# Altium schematic sheet RTC.SchDoc(RTC)
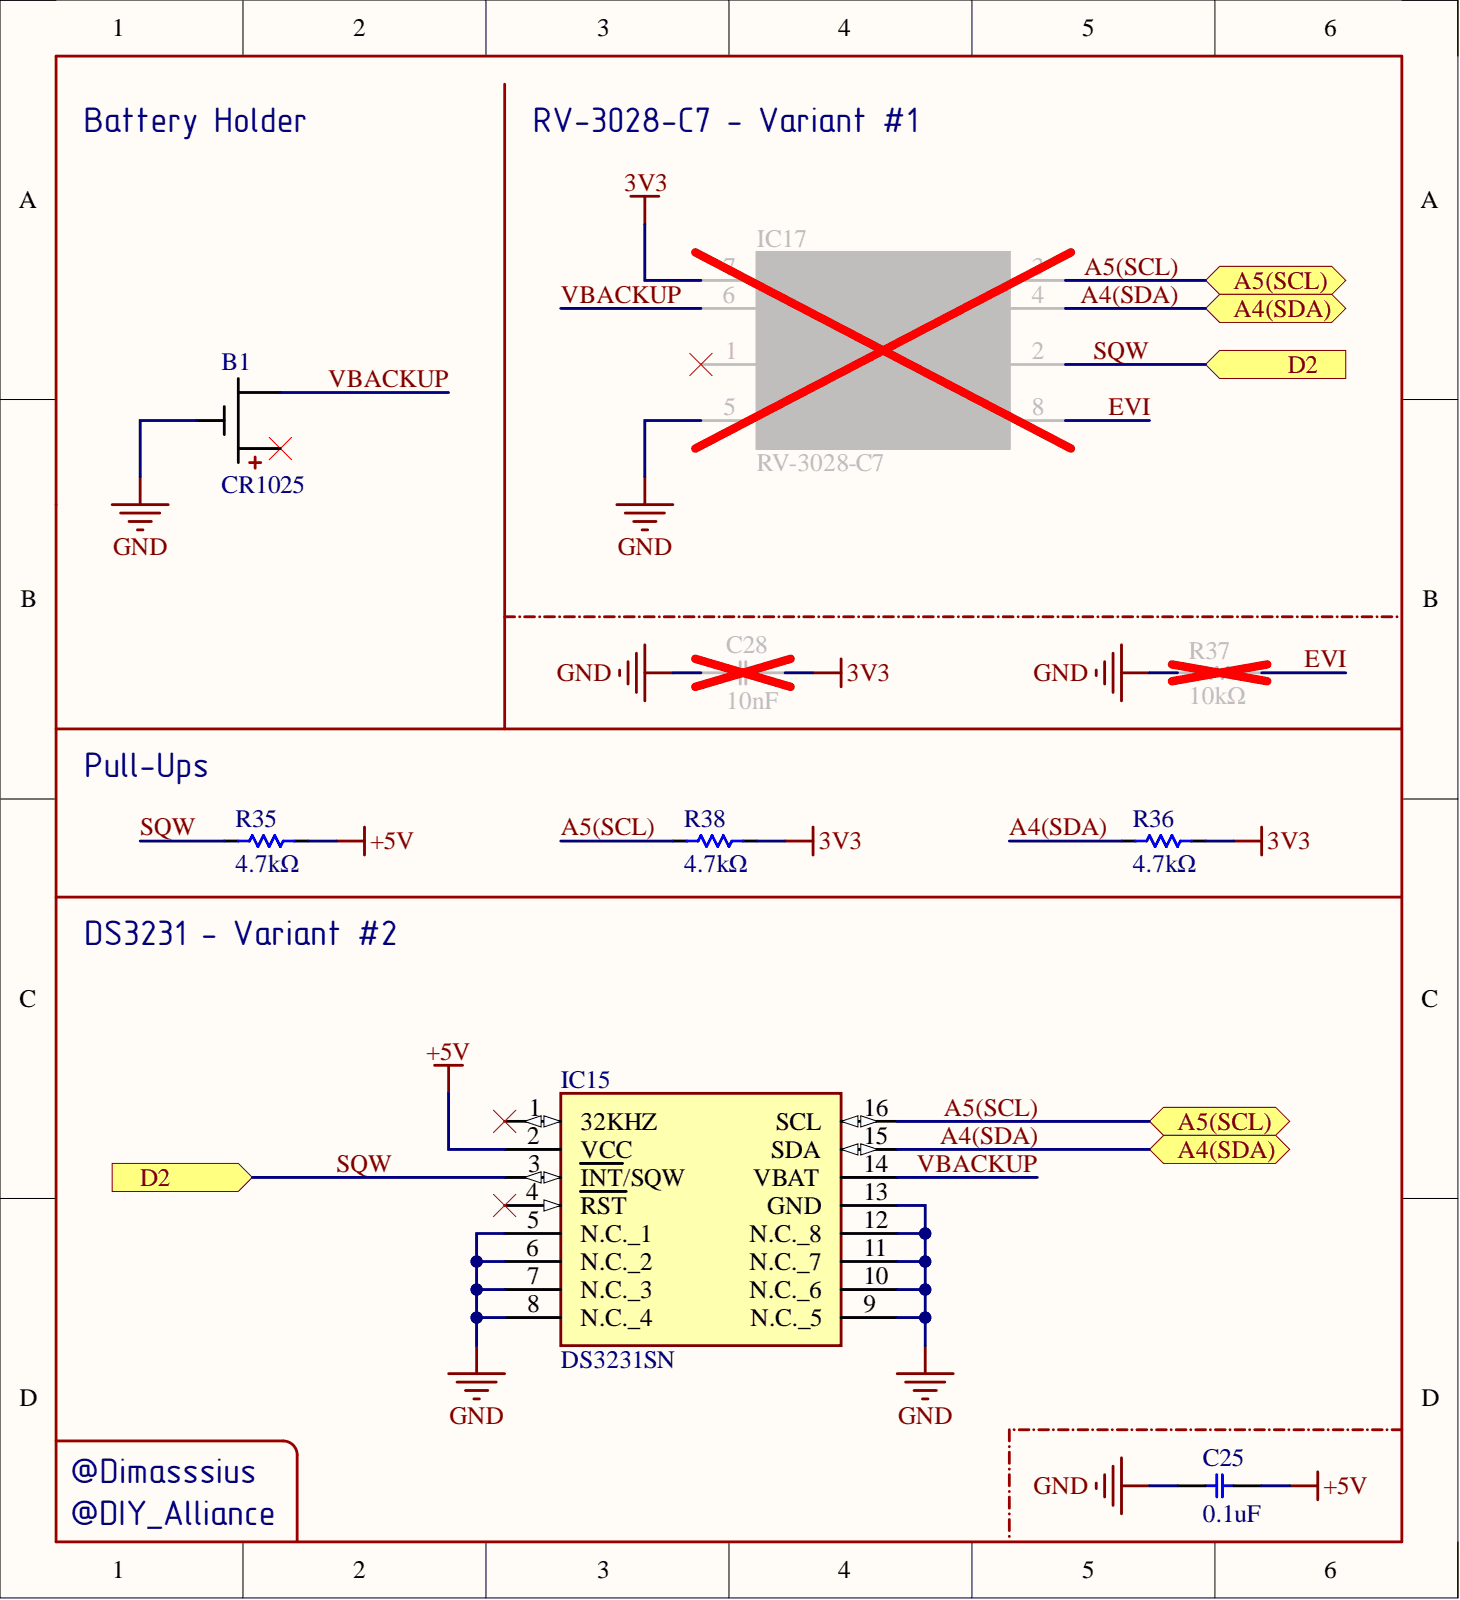
[diagram p0: RTC.SchDoc]

## Parts
B1  CR1025  [CR1025]
C25  0.1uF  [CAP_SMD_MLCC_C0603_(M1608)_C0402A_(M1005A)]
C28  10nF  [CAP_SMD_MLCC_C0603_(M1608)_C0402A_(M1005A)]
IC15  DS3231SN  [SOIC127P1032X265-16N]
IC17  RV-3028-C7  [SMD3215-8P]
R35  4.7kΩ  [RES_SMD_R0603_(M1608)_R0402A_(M1005A)]
R36  4.7kΩ  [RES_SMD_R0603_(M1608)_R0402A_(M1005A)]
R37  10kΩ  [RES_SMD_R0603_(M1608)_R0402A_(M1005A)]
R38  4.7kΩ  [RES_SMD_R0603_(M1608)_R0402A_(M1005A)]

## Ports
A4(SDA), A5(SCL), D2

## Nets
3V3: C28.2 IC17.7(VDD) R36.1 R38.2
+5V: C25.1 IC15.2(VCC) R35.2
GND: B1.1 C25.2 C28.1 IC15.5(N.C._1) IC15.6(N.C._2) IC15.7(N.C._3) IC15.8(N.C._4) IC15.9(N.C._5) IC15.10(N.C._6) IC15.11(N.C._7) IC15.12(N.C._8) IC15.13(GND) IC17.5(GND) R37.2
A4(SDA): IC15.15(SDA) IC17.4(SDA) R36.2 ; port A4(SDA)
A5(SCL): IC15.16(SCL) IC17.3(SCL) R38.1 ; port A5(SCL)
EVI: IC17.8(EVI) R37.1
SQW: IC15.3(INT/SQW) IC17.2(INT) R35.1 ; port D2
VBACKUP: B1.3 IC15.14(VBAT) IC17.6(VBACKUP)

Not connected: B1 2; IC15 1(32KHZ) 4(RST); IC17 1(CLKOUT)

## Library data of the parts
B1 = CR1025: Battery Clip Coin, SMD, CR1025, Cell; Component Kind: Standard; Library Name: SamacSys.SchLib; Value: CR1025
C25 = CAP_SMD_MLCC_0.1UF_6.3V: Capacitor, Ceramic (MLCC), SMD, 100nF, 6.3V, ±10%, X7R, Multilayer Ceramic Capacitors MLCC - SMD/SMT, ROHS; Manufacturer: Murata Electronics North America; Part Number: LLM315R71C104MA11L; @ qty: 0; Minimum Quantity: 1; Tolerance: ±20%; Unit Price (USD): 0.9; Voltage: 16V; Applications: Bypass, Decoupling; Component Kind: Standard; Datasheets: http://www.murata.com/~/media/webrenewal/support/library/catalog/products/capacitor/mlcc/c02e.pdf; Digi-Key Part Number: 490-4495-1-ND; Factory Stock: 0; Features: Low ESL (Multi-Terminal); Height - Seated (Max): -; Image: //media.digikey.com/Renders/Murata%20Renders/1206(LLM315).jpg; Lead Spacing: -; Lead Style: -; Library Name: Capacitors.SchLib; Mounting Type: Surface Mount, MLCC; Operating Temperature: -55°C ~ 125°C; Package / Case: -; Packaging: Cut Tape (CT); Part Status: Active; Quantity Available: 2915; Ratings: -; Series: LLM; Size / Dimension: -; Temperature Coefficient: X7R; Thickness (Max): -; Value: 0.1uF
C28 = CAP_SMD_MLCC_10NF_50V: Capacitor, Ceramic (MLCC), SMD, 10nF, 50V, ±10%, X7R, Multilayer Ceramic Capacitors MLCC - SMD/SMT, ROHS; Manufacturer: Murata Electronics North America; Part Number: GRM31CR60J106KA01L; @ qty: 0; Minimum Quantity: 1; Temperature Coefficient: X5R; Unit Price (USD): 0.24; Voltage: 6.3V; Applications: General Purpose; Component Kind: Standard; Datasheets: http://www.murata.com/~/media/webrenewal/support/library/catalog/products/capacitor/mlcc/c02e.pdf; Digi-Key Part Number: 490-12610-1-ND; Factory Stock: 0; Features: -; Height - Seated (Max): -; Image: //media.digikey.com/Renders/Murata%20Renders/GR331BD72E473KW01L.jpg; Lead Spacing: -; Lead Style: -; Library Name: Capacitors.SchLib; Mounting Type: Surface Mount, MLCC; Operating Temperature: -55°C ~ 85°C; Package / Case: -; Packaging: Cut Tape (CT); Part Status: Active; Quantity Available: 12001; Ratings: -; Series: GRM; Size / Dimension: -; Thickness (Max): -; Tolerance: ±10%; Value: 10nF
IC15 = DS3231SN: Real Time Clock, SOIC-16-300mil, I2C, Real Time Clocks, ROHS; Component Kind: Standard; Datasheet Link: https://datasheet.datasheetarchive.com/originals/distributors/Datasheets-8/DSA-148585.pdf; Height: 2.65mm; Library Name: SamacSys.SchLib; Value: DS3231SN
IC17 = RV-3028-C7: Real Time Clock, SMD3215-8P, I2C, Real Time Clocks, ROHS; Manufacturer_Name: Micro Crystal AG; Component Kind: Standard; Datasheet Link: https://www.microcrystal.com/fileadmin/Media/Products/RTC/Datasheet/RV-3028-C7.pdf; Height: 0.8mm; Library Name: SamacSys.SchLib; Manufacturer_Part_Number: RV-3028-C7; Value: RV-3028-C7
R35 R36 R38 = RES_SMD_4.7KΩ: Resistor, Thick Film Resistor, SMD, 4.7kΩ, ±5%, Chip Resistor - Surface Mount, ROHS; Component Kind: Standard; Library Name: Resistors.SchLib; Value: 4.7kΩ
R37 = RES_SMD_10KΩ: Resistor, Thick Film Resistor, SMD, 10kΩ, ±5%, Chip Resistor - Surface Mount, ROHS; Component Kind: Standard; Library Name: Resistors.SchLib; Value: 10kΩ
